# Altium schematic sheet CASSA-SER.SchDoc(CASSA-SER)
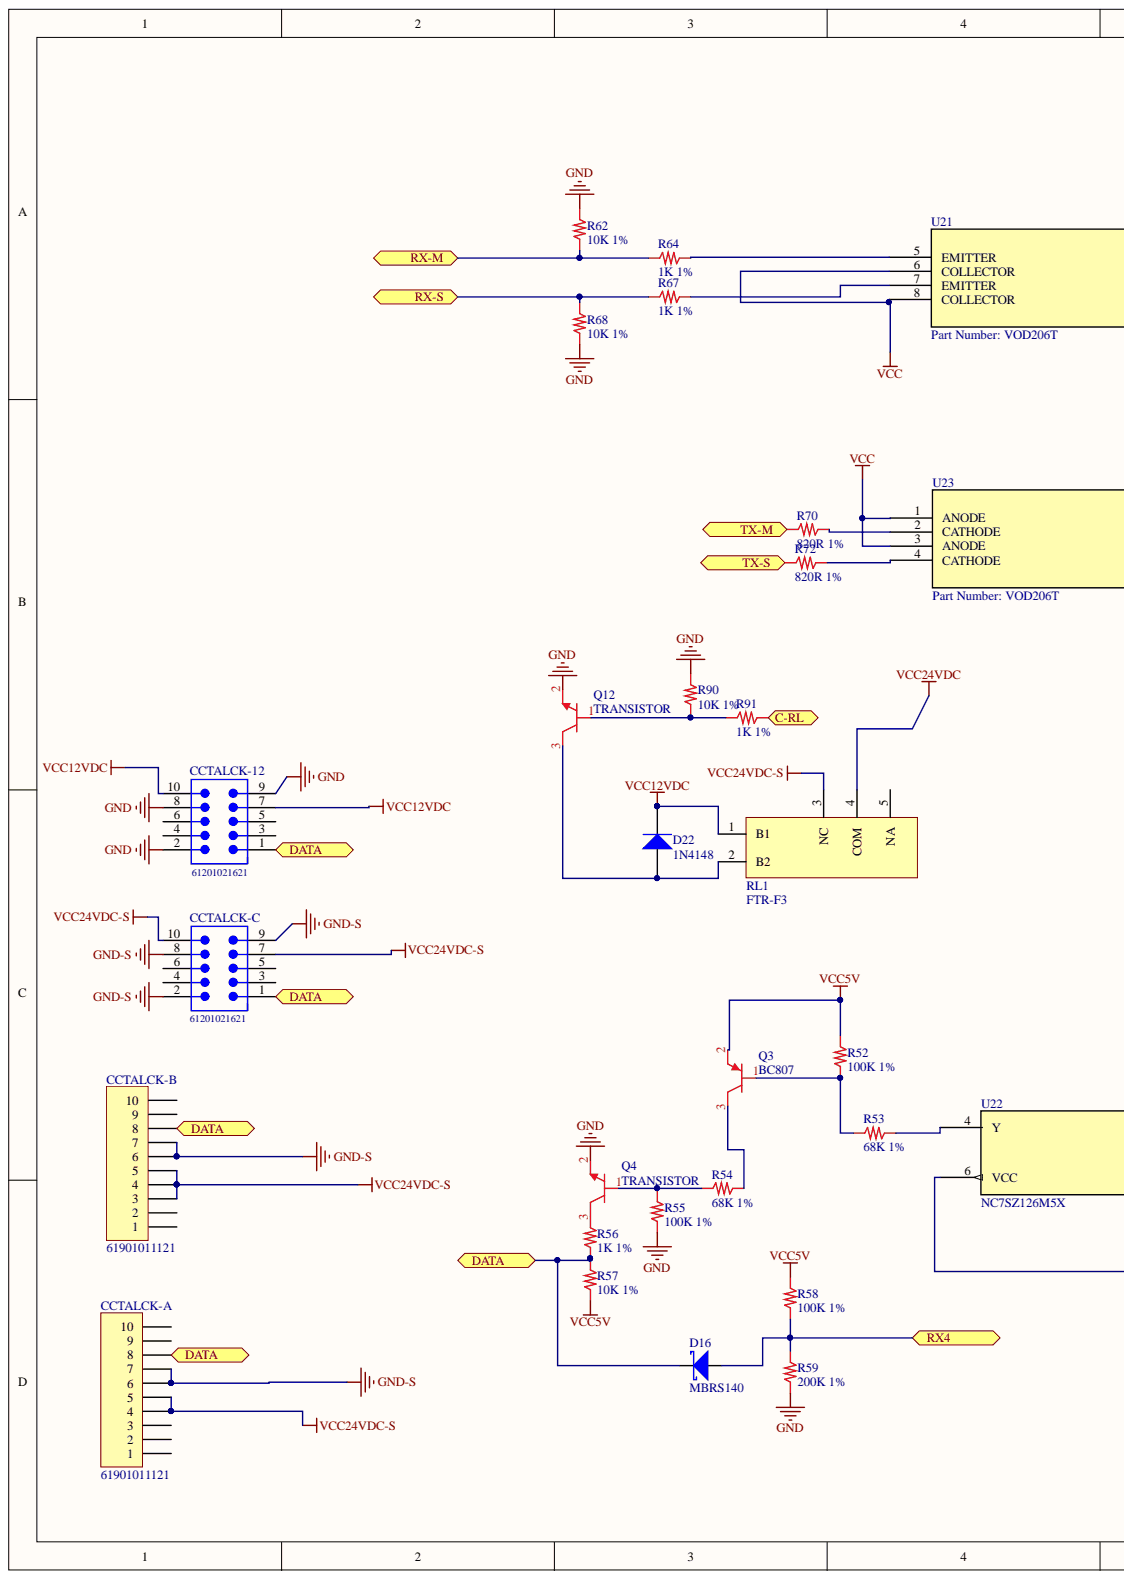
[diagram p0: CASSA-SER.SchDoc, left]
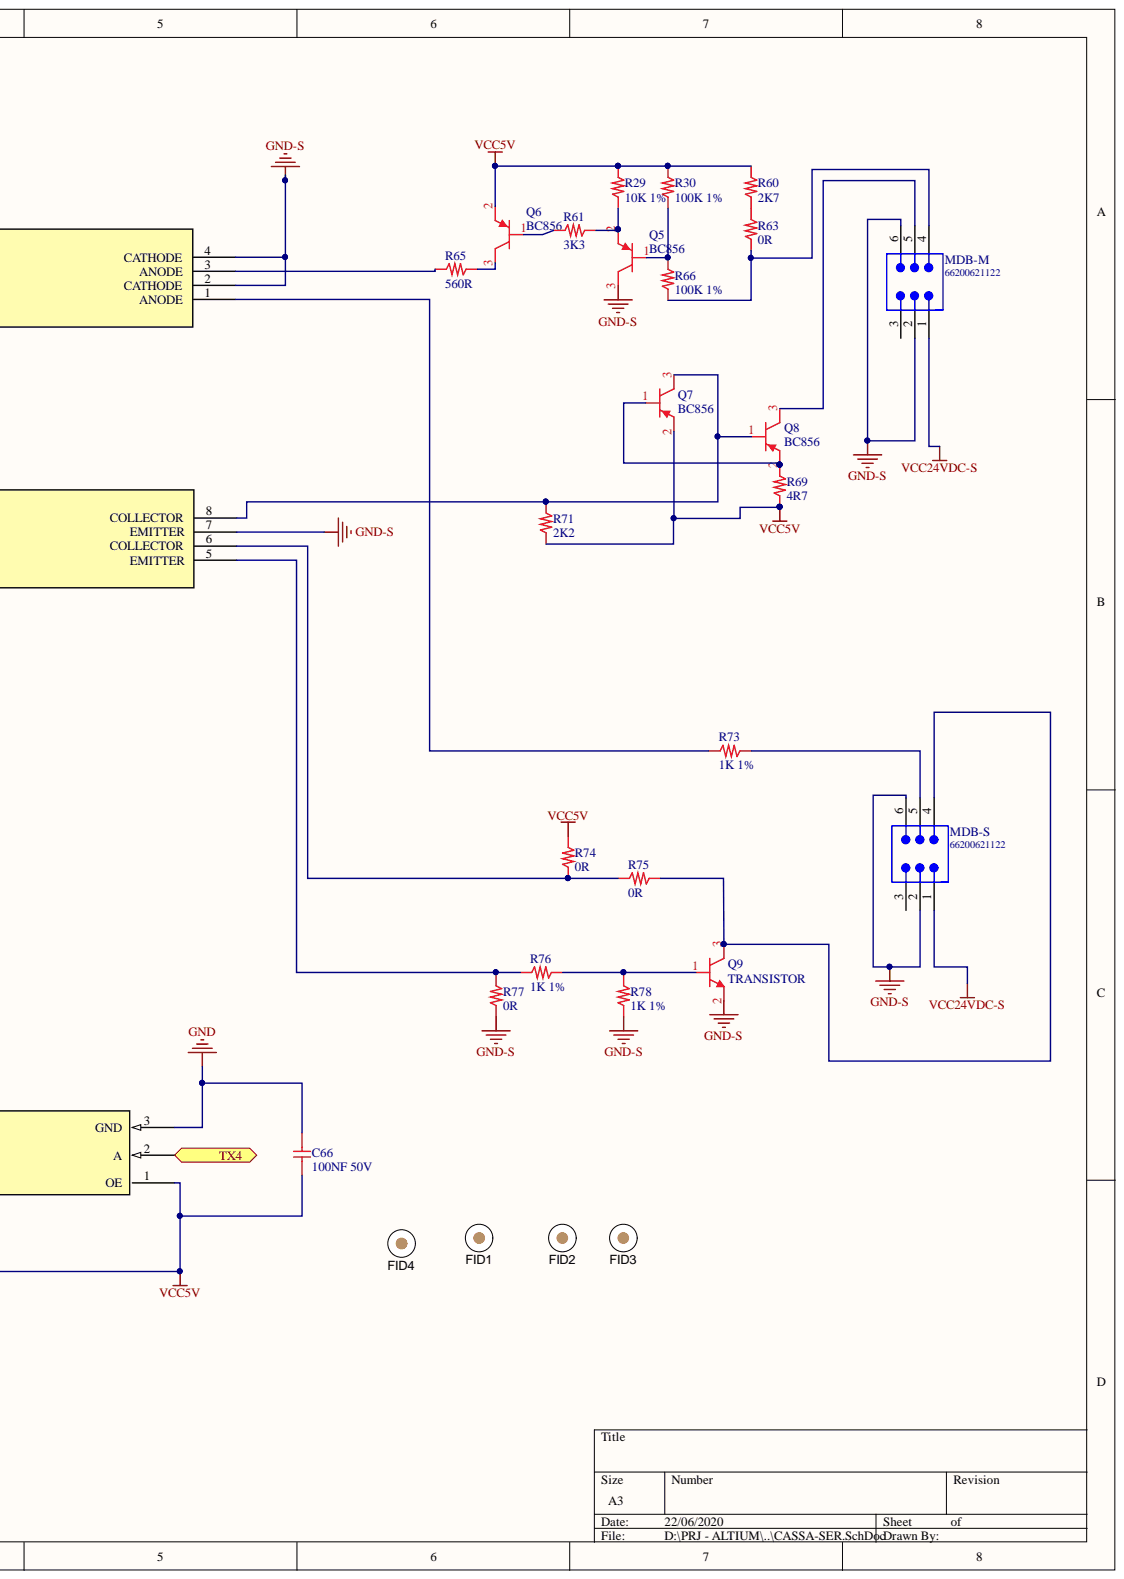
[diagram p1: CASSA-SER.SchDoc, right]

## Parts
C66  100NF 50V  [0603_c]
CCTALCK-12  61201021621  [61201021621]
CCTALCK-A  61901011121  [61901011121]
CCTALCK-B  61901011121  [61901011121]
CCTALCK-C  61201021621  [61201021621]
D16  MBRS140  [SMB]
D22  1N4148  [1N4148]
FID1  Fiducial  [Fiducial10-20]  (value not drawn)
FID2  Fiducial  [Fiducial10-20]  (value not drawn)
FID3  Fiducial  [Fiducial10-20]  (value not drawn)
FID4  Fiducial  [Fiducial10-20]  (value not drawn)
MDB-M  66200621122  [66200621122]
MDB-S  66200621122  [66200621122]
Q3  BC807  [SOT23]
Q4  TRANSISTOR  [SOT23]
Q5  BC856  [SOT23]
Q6  BC856  [SOT23]
Q7  BC856  [SOT23]
Q8  BC856  [SOT23]
Q9  TRANSISTOR  [SOT23]
Q12  TRANSISTOR  [SOT23]
R29  10K 1%  [0603_R]
R30  100K 1%  [0603_R]
R52  100K 1%  [0603_R]
R53  68K 1%  [0603_R]
R54  68K 1%  [0603_R]
R55  100K 1%  [0603_R]
R56  1K 1%  [0603_R]
R57  10K 1%  [0603_R]
R58  100K 1%  [0603_R]
R59  200K 1%  [0603_R]
R60  2K7  [0603_R]
R61  3K3  [0603_R]
R62  10K 1%  [0603_R]
R63  0R  [0603_R]
R64  1K 1%  [0603_R]
R65  560R  [0603_R]
R66  100K 1%  [0603_R]
R67  1K 1%  [0603_R]
R68  10K 1%  [0603_R]
R69  4R7  [0603_R]
R70  820R 1%  [0603_R]
R71  2K2  [0603_R]
R72  820R 1%  [0603_R]
R73  1K 1%  [0603_R]
R74  0R  [0603_R]
R75  0R  [0603_R]
R76  1K 1%  [0603_R]
R77  0R  [0603_R]
R78  1K 1%  [0603_R]
R90  10K 1%  [0603_R]
R91  1K 1%  [0603_R]
RL1  FTR-F3  [FTR-F3-NC-NA]
U21  Part Number: VOD206T  [SOIC-8_VO]
U22  NC7SZ126M5X  [SOT23-5_N]
U23  Part Number: VOD206T  [SOIC-8_VO]

## Ports
C-RL, DATA, RX4, RX-M, RX-S, TX4, TX-M, TX-S

## Nets
GND: C66.1 CCTALCK-12.2 CCTALCK-12.8 CCTALCK-12.9 Q4.2 Q12.2 R55.2 R59.2 R62.1 R68.2 R90.1 U22.3(GND)
GND-S: CCTALCK-A.6 CCTALCK-A.7 CCTALCK-B.6 CCTALCK-B.7 CCTALCK-C.2 CCTALCK-C.8 CCTALCK-C.9 MDB-M.2 MDB-M.6 MDB-S.2 MDB-S.6 Q5.3 Q9.2 R77.1 R78.2 U21.2(CATHODE) U21.4(CATHODE) U23.7(EMITTER)
VCC: U21.6(COLLECTOR) U21.8(COLLECTOR) U23.1(ANODE) U23.3(ANODE)
VCC5V: C66.2 Q3.2 Q6.2 Q7.2 R29.1 R30.1 R52.1 R57.2 R58.1 R60.1 R69.2 R71.2 R74.2 U22.1(OE) U22.6(VCC)
VCC12VDC: CCTALCK-12.7 CCTALCK-12.10 D22.2 RL1.1(B1)
VCC24VDC: RL1.4(COM)
VCC24VDC-S: CCTALCK-A.4 CCTALCK-A.5 CCTALCK-B.3 CCTALCK-B.4 CCTALCK-B.5 CCTALCK-C.7 CCTALCK-C.10 MDB-M.1 MDB-S.1 RL1.3(NC)
C-RL: R91.2 ; port C-RL
DATA: CCTALCK-12.1 CCTALCK-A.8 CCTALCK-B.8 CCTALCK-C.1 D16.K R56.2 R57.1 ; port DATA
RX4: D16.A R58.2 R59.1 ; port RX4
RX-M: R62.2 R64.1 ; port RX-M
RX-S: R67.1 R68.1 ; port RX-S
TX4: U22.2(A) ; port TX4
TX-M: R70.1 ; port TX-M
TX-S: R72.1 ; port TX-S
NetD22_1: D22.1 Q12.3 RL1.2(B2)
NetMDB-M_4: MDB-M.4 R63.2 R66.2
NetMDB-M_5: MDB-M.5 Q8.3
NetMDB-S_4: MDB-S.4 Q9.3 R75.2
NetMDB-S_5: MDB-S.5 R73.2
NetQ3_1: Q3.1 R52.2 R53.1
NetQ3_3: Q3.3 R54.2
NetQ4_1: Q4.1 R54.1 R55.1
NetQ4_3: Q4.3 R56.1
NetQ5_1: Q5.1 R30.2 R66.1
NetQ5_2: Q5.2 R29.2 R61.2
NetQ6_1: Q6.1 R61.1
NetQ6_3: Q6.3 R65.2
NetQ7_1: Q7.1 Q8.2 R69.1
NetQ7_3: Q7.3 Q8.1 R71.1 U23.8(COLLECTOR)
NetQ9_1: Q9.1 R76.2 R78.1
NetQ12_1: Q12.1 R90.2 R91.1
NetR53_2: R53.2 U22.4(Y)
NetR60_2: R60.2 R63.1
NetR64_2: R64.2 U21.5(EMITTER)
NetR65_1: R65.1 U21.3(ANODE)
NetR67_2: R67.2 U21.7(EMITTER)
NetR70_2: R70.2 U23.2(CATHODE)
NetR72_2: R72.2 U23.4(CATHODE)
NetR73_1: R73.1 U21.1(ANODE)
NetR74_1: R74.1 R75.1 U23.6(COLLECTOR)
NetR76_1: R76.1 R77.2 U23.5(EMITTER)

Not connected: CCTALCK-12 3 4 5 6; CCTALCK-A 1 2 3 9 10; CCTALCK-B 1 2 9 10; CCTALCK-C 3 4 5 6; MDB-M 3; MDB-S 3; RL1 5(NA)

## Library data of the parts
C66 = 100NF 50V: Cap X7R 100nF 50V Flex Terminal; Produttore: Samsung; Cod. Produttore: CL10B104KB8SFNC; Cod. Interno: COCS50665050104; Library Name: CapSMD0603.SchLib; Component Kind: Standard; Cod. DigiKey: 1276-1936-2-ND
CCTALCK-12 CCTALCK-C = 61201021621: Male Box Header WR-BHD, THT, Vertical, pitch 2.54 mm, 10 pins; Working Voltage: 250 VAC; Withstanding Voltage: 500 VAC; Pitch: 2.54 mm; Pin Number: 10; Contact Resistance: 20 mOhm; Published Date: August 2018; Part Number: 61201021621; Packaging: Tray; Orientation: Vertical; Operating Temperature: -40 to 125 degC; Mounting Technology: THT; Gender: Male; ComponentLink2URL: https://katalog.we-online.de/em/datasheet/6120xx21621.pdf; ComponentLink2Description: Datasheet; ComponentLink1URL: http://www.we-online.de; ComponentLink1Description: Manufacturer; Component Kind: Standard; Publisher: Wurth Elektronik; Library Name: CONN-FLAT.SchLib
CCTALCK-A CCTALCK-B = 61901011121: WR-WTB 2.54mm Male Locking Header, 10p; Pin Number: 10; Published Date: June 2017; Pitch: 2.54 mm; Part Number: 61901011121; ComponentLink2URL: http://katalog.we-online.de/em/datasheet/6190xx11121.pdf; ComponentLink2Description: Datasheet; ComponentLink1URL: http://www.we-online.de; ComponentLink1Description: Manufacturer; Component Kind: Standard; Publisher: Wurth Elektronik; Library Name: CONNETTORE-KK-2,54.SchLib
D16 = MBRS140: Schottky Diode; Simulation: SKYDIODE; Published: 8-Jun-2000; PackageReference: SMB; PackageDescription: DO-214-AA/SMB; 2 C-Bend Leads; Body 5.3 x 3.6 mm, inc. leads (LxW); LatestRevisionNote: Re-released for DXP Platform.; LatestRevisionDate: 17-Jul-2002; Component Kind: Standard; Code_JEDEC: DO-214-AA; Publisher: Altium Limited; Library Name: HSW.SchLib
D22 = 1N4148: High Conductance Fast Diode; Published: 8-Jun-2000; PackageReference: DO-35; PackageDescription: Diode, Axial; Body 3.8 x 1.9 mm (LxDia. max), Lead Dia. 0.53 mm (max); LatestRevisionNote: Re-released for DXP Platform.; LatestRevisionDate: 17-Jul-2002; DatasheetVersion: 1997; ComponentLink2URL: http://www.fairchildsemi.com/ds/1N%2F1N4148.pdf; ComponentLink2Description: Datasheet; ComponentLink1URL: http://www.fairchildsemi.com/; ComponentLink1Description: Manufacturer Link; Component Kind: Standard; Code_JEDEC: DO-204-AH; Publisher: Altium Limited; Library Name: HSW.SchLib
FID1-FID4 = Fiducial: Fiducial mark.  There is nothing to buy or mount.; SymbolCreator: codlsc; SymbolVersion: 2009-11-4; PartNumber: N/A; PackageReference: N/A; MountType: N/A; Manufacturer: N/A; LatestRevisionNote: Added Alternate PartNumber and Alternate Manufacturer parameters to appropriate components.; LatestRevisionDate: 2015-10-18; CompType: Fiducial; Component Kind: Standard; ClassName: FiducialMark; Publisher: Texas Instruments; Library Name: HSW.SchLib
MDB-M MDB-S = 66200621122: THT Male Header WR-MPC3, Vertical, Dual Row, pitch 3 mm, 6 pins; Working Voltage: 250 VAC; Pitch: 3 mm; Pin Number: 6; Contact Resistance: 10 mOhm; Published Date: September 2018; Part Number: 66200621122; Packaging: Tube; Orientation: Vertical; Operating Temperature: -40 to 105 degC; Mounting Technology: THT; Library Name: WR-MPC3.SchLib; Gender: Male; ComponentLink2URL: https://katalog.we-online.de/em/datasheet/6620xx21122.pdf; ComponentLink2Description: Datasheet; ComponentLink1URL: http://www.we-online.de; ComponentLink1Description: Manufacturer; Component Kind: Standard; Publisher: Wurth Elektronik
Q3 Q5-Q8 = BC856: PNP Si Digital Transistor; Vceo: -45V; Vcbo: -50V; Ptot: 225mW; Produttore: OnSemi; Ic: -0.5A; Cod. Produttore: BC807-40LT1G; Component Kind: Standard; Cod. DigiKey: BC807-40LT1GOSTR-ND; Library Name: HSW.SchLib
Q4 Q9 Q12 = BC847B: NPN Silicon Darlington Transistors; Vce: 30V; Vcb: 40V; Produttore: INFINEON; Ic: 500mA; Cod. Produttore: BCV27; Cod. Interno: TRXS ??; Component Kind: Standard; Library Name: HSW.SchLib
R29 R57 R62 R68 R90 = 10K 1%: Res Film Spesso 1/16W 10K 1%; Produttore: Panasonic; Cod. Produttore: ERJ-3EKF1002V; Cod. Interno: RESS4166356B0103; Library Name: ResSMD0603.SchLib; Component Kind: Standard
R30 R52 R55 R58 R66 = 100K 1%: Res Film Spesso 1/16W 100K 1%; Produttore: ??; Cod. Interno: RESS4166360C0104; Library Name: ResSMD0603.SchLib; Component Kind: Standard; Cod. Produttore: ??
R53 R54 = 68K 1%: Res Film Spesso 1/16W 68K 1%; Produttore: Vishay; Cod. Produttore: MCT06030C6802FP500; Library Name: ResSMD0603.SchLib; Component Kind: Standard; Cod. DigiKey: MCT0603-68.0K-CFTR-ND
R56 R64 R67 R73 R76 R78 R91 = 1K 1%: Res Film Spesso 1/16W 1K 1%; Produttore: ??; Cod. Interno: RESS4166356B0102; Library Name: ResSMD0603.SchLib; Component Kind: Standard; Cod. Produttore: ??
R59 = 200K 1%: Res Film Spesso 1/16W 100K 1%; Produttore: ??; Cod. Interno: RESS4166360C0104; Library Name: ResSMD0603.SchLib; Component Kind: Standard; Cod. Produttore: ??
R60 = 2K7: Res Film Spesso 1/16W 2K7 5%; Produttore: VISHAY; Cod. Produttore: CRCW06032K70JNEA; Cod. Interno: RESS4166556B0272; Library Name: ResSMD0603.SchLib; Component Kind: Standard
R61 = 3K3: Res Film Spesso 1/16W 3K3 5%; Produttore: ??; Cod. Interno: RESS4166556B0332; Library Name: ResSMD0603.SchLib; Component Kind: Standard; Cod. Produttore: ??
R63 R74 R75 R77 = 0R: Res Film Spesso 1/16W 0R 5%; Cod. Interno: RESS 4166556B0000; Library Name: ResSMD0603.SchLib; Component Kind: Standard
R65 = 560R: Res Film Spesso 1/16W 560R 5%; Cod. Interno: RESS 4166556B0561; Library Name: ResSMD0603.SchLib; Component Kind: Standard
R69 = 4R7: Res Film Spesso 1/16W 47R 5%; Produttore: ??; Cod. Interno: RESS4166556B0047??; Library Name: ResSMD0603.SchLib; Component Kind: Standard; Cod. Produttore: ??
R70 R72 = 820R 1%: Res Film Spesso 1/16W 820R 1%; Produttore: ??; Cod. Interno: RESS4166356B0681; Library Name: ResSMD0603.SchLib; Component Kind: Standard; Cod. Produttore: ??
R71 = 2K2: Res Film Spesso 1/16W 2K2 5%; Produttore: ??; Cod. Interno: RESS4166556B0222; Library Name: ResSMD0603.SchLib; Component Kind: Standard; Cod. Produttore: ??
RL1 = FTR-F3-NC-NA: RELE 12VDC NC-NA; Component Kind: Standard; Library Name: HSW.SchLib
U21 U23 = VOD206T: No Description Available; Vendor: Vishay; Built_By: EMA_UL_Team; Value: Value; Type: DEV; RefDes: RefDes; Manufacturer_Part_Number: VOD206T; Component Kind: Standard; Library Name: HSW.SchLib; Copyright: Copyright (C) 2018 Accelerated Designs. All rights reserved
U22 = NC7SZ126M5X: No Description Available; Manufacturer_Part_Number: SN74LVC1G125DBVR; Vendor: Texas Instruments; Built_By: EMA_UL_Team; Value: Value; Type: DEV; RefDes: RefDes; Component Kind: Standard; Library Name: HSW.SchLib; Copyright: Copyright (C) 2018 Accelerated Designs. All rights reserved
